# Altium schematic sheet 19internal_Mainboard.SchDoc(19internal_Mainboard)
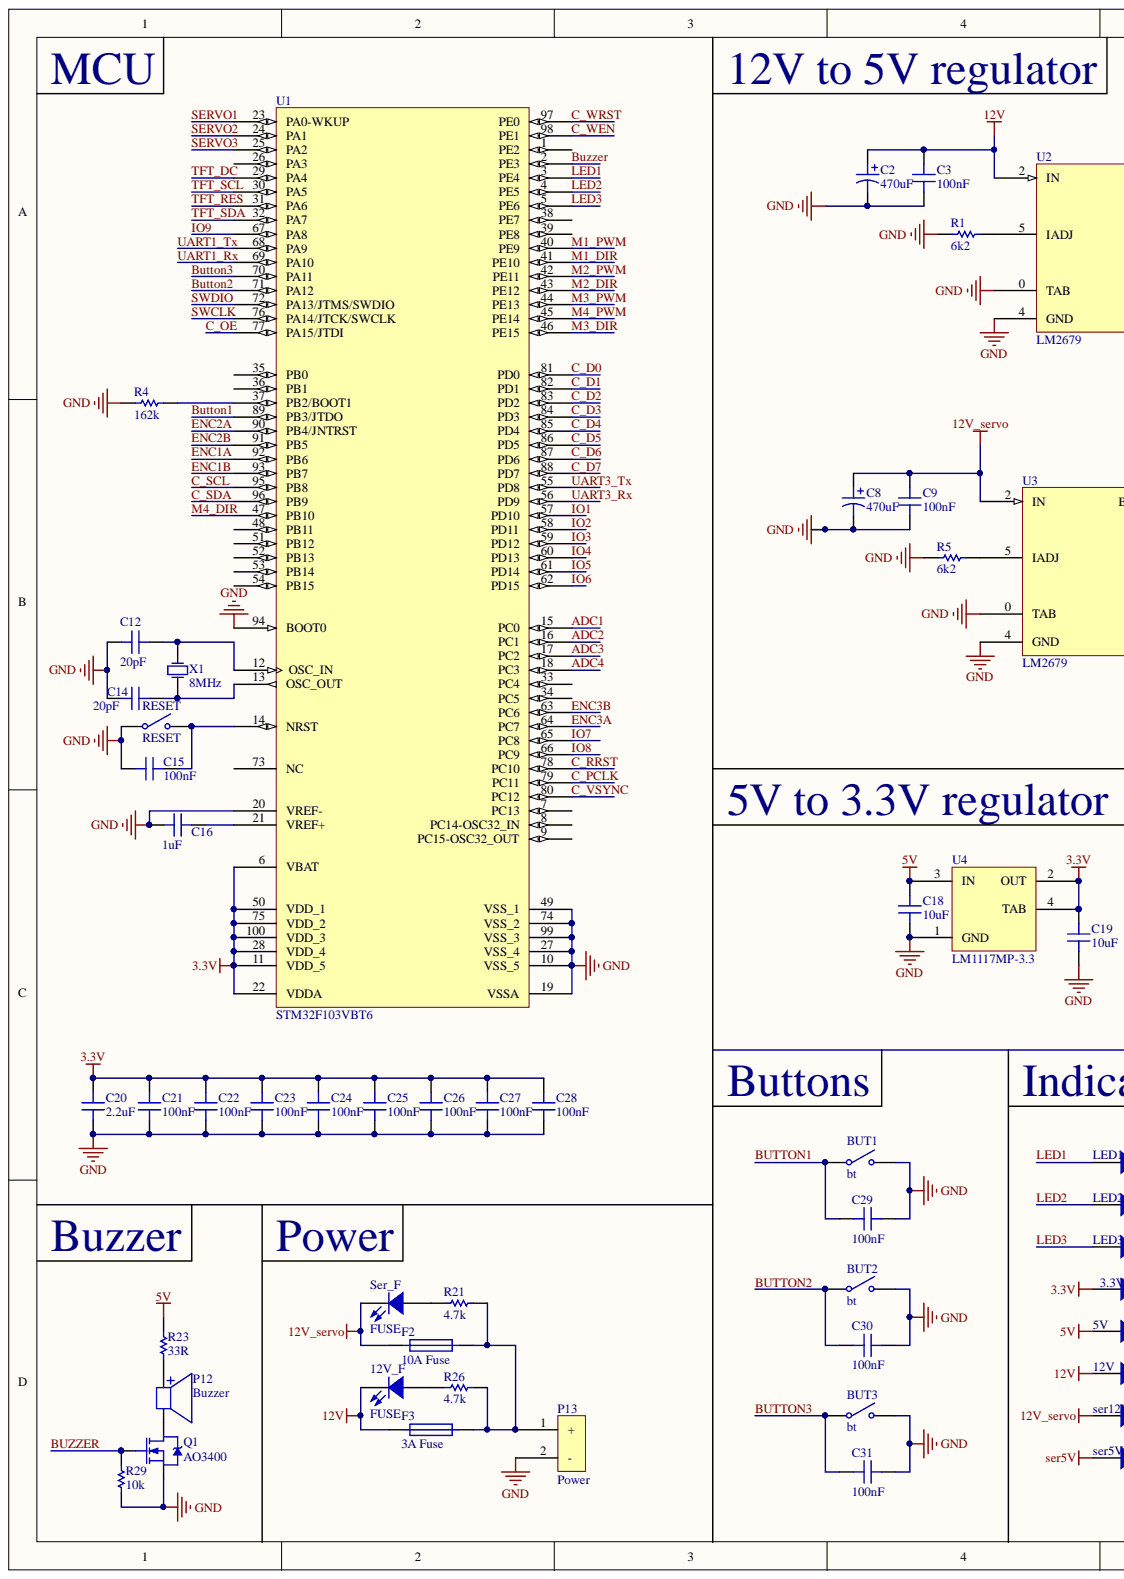
[diagram p0: 19internal_Mainboard.SchDoc, left]
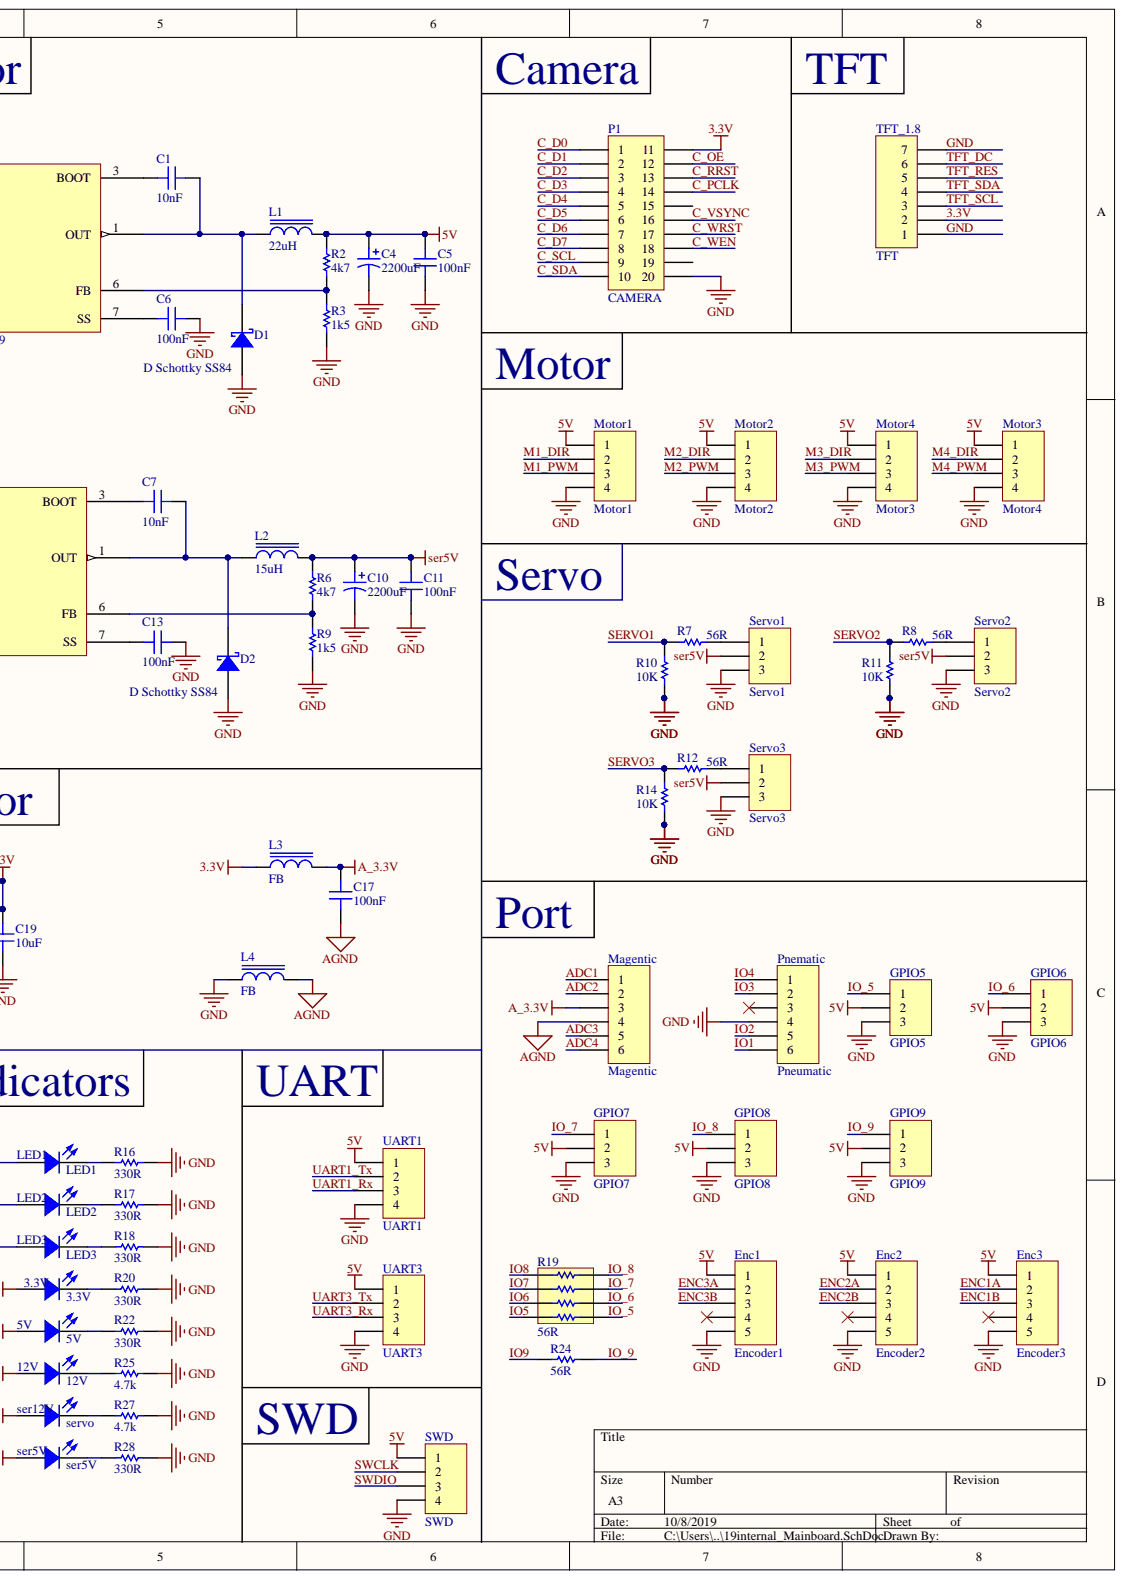
[diagram p1: 19internal_Mainboard.SchDoc, right]

## Parts
3.3V  3.3V  [D0805]
5V  5V  [D0805]
12V  12V  [D0805]
12V_F  FUSE  [D0805]
BUT1  bt  [PUSH SWITCH]
BUT2  bt  [PUSH SWITCH]
BUT3  bt  [PUSH SWITCH]
C1  10nF  [CC2012-0805]
C2  470uF  [CAP10x12]
C3  100nF  [CC2012-0805]
C4  2200uF  [SV-H]
C5  100nF  [CC2012-0805]
C6  100nF  [CC2012-0805]
C7  10nF  [CC2012-0805]
C8  470uF  [CAP10x12]
C9  100nF  [CC2012-0805]
C10  2200uF  [SV-H]
C11  100nF  [CC2012-0805]
C12  20pF  [CC2012-0805]
C13  100nF  [CC2012-0805]
C14  20pF  [CC2012-0805]
C15  100nF  [CC2012-0805]
C16  1uF  [CC2012-0805]
C17  100nF  [CC3216-1206]
C18  10uF  [CC2012-0805]
C19  10uF  [CC2012-0805]
C20  2.2uF  [CC2012-0805]
C21  100nF  [CC2012-0805]
C22  100nF  [CC2012-0805]
C23  100nF  [CC2012-0805]
C24  100nF  [CC2012-0805]
C25  100nF  [CC2012-0805]
C26  100nF  [CC2012-0805]
C27  100nF  [CC2012-0805]
C28  100nF  [CC2012-0805]
C29  100nF  [CC2012-0805]
C30  100nF  [CC2012-0805]
C31  100nF  [CC2012-0805]
D1  D Schottky SS84  [SMC]
D2  D Schottky SS84  [SMC]
Enc1  Encoder1  [lockedHDR-5]
Enc2  Encoder2  [lockedHDR-5]
Enc3  Encoder3  [lockedHDR-5]
F2  10A Fuse  [FUSE SOCKET]
F3  3A Fuse  [FUSE SOCKET]
GPIO5  GPIO5  [LOCKEDHDR-3]
GPIO6  GPIO6  [LOCKEDHDR-3]
GPIO7  GPIO7  [LOCKEDHDR-3]
GPIO8  GPIO8  [LOCKEDHDR-3]
GPIO9  GPIO9  [LOCKEDHDR-3]
L1  22uH  [MSS1260]
L2  15uH  [MSS1260]
L3  FB  [CL3225-1210]
L4  FB  [CL3225-1210]
LED1  LED1  [D0805]
LED2  LED2  [D0805]
LED3  LED3  [D0805]
Magentic  Magentic  [6410-06]
Motor1  Motor1  [LOCKEDHDR-4]
Motor2  Motor2  [LOCKEDHDR-4]
Motor3  Motor4  [LOCKEDHDR-4]
Motor4  Motor3  [LOCKEDHDR-4]
P1  CAMERA  [HDR2X10_CEN]
P12  Buzzer  [BUZ-9.0]
P13  Power  [XT60]
Pnematic  Pneumatic  [6410-06]
Q1  AO3400  [SO-G3/Z3.3]
R1  6k2  [CR2012-0805]
R2  4k7  [CR2012-0805]
R3  1k5  [CR2012-0805]
R4  162k  [CR2012-0805]
R5  6k2  [CR2012-0805]
R6  4k7  [CR2012-0805]
R7  56R  [CR2012-0805]
R8  56R  [CR2012-0805]
R9  1k5  [CR2012-0805]
R10  10K  [CR2012-0805]
R11  10K  [CR2012-0805]
R12  56R  [CR2012-0805]
R14  10K  [CR2012-0805]
R16  330R  [CR2012-0805]
R17  330R  [CR2012-0805]
R18  330R  [CR2012-0805]
R19  56R  [ARC241-0603x4]
R20  330R  [CR2012-0805]
R21  4.7k  [CR2012-0805]
R22  330R  [CR2012-0805]
R23  33R  [CR2012-0805]
R24  56R  [CR2012-0805]
R25  4.7k  [CR2012-0805]
R26  4.7k  [CR2012-0805]
R27  4.7k  [CR2012-0805]
R28  330R  [CR2012-0805]
R29  10k  [CR2012-0805]
RESET  RESET  [PUSH SWITCH 2-PIN]
ser5V  ser5V  [D0805]
ser12V  servo  [D0805]
Ser_F  FUSE  [D0805]
Servo1  Servo1  [LOCKEDHDR-3]
Servo2  Servo2  [LOCKEDHDR-3]
Servo3  Servo3  [LOCKEDHDR-3]
SWD  SWD  [LOCKEDHDR-4]
TFT_1.8  TFT  [HDR1X7]
U1  STM32F103VBT6  [LQFP100_L]
U2  LM2679  [TO-263(7)]
U3  LM2679  [TO-263(7)]
U4  LM1117MP-3.3  [MP04A_N]
UART1  UART1  [LOCKEDHDR-4]
UART3  UART3  [LOCKEDHDR-4]
X1  8MHz  [BCY-W2/E4.7]

## Nets
5V: 5V.1 C4.1 C5.1 C18.2 Enc1.1 Enc2.1 Enc3.1 GPIO5.2 GPIO6.2 GPIO7.2 GPIO8.2 GPIO9.2 L1.2 Motor1.1 Motor2.1 Motor3.1 Motor4.1 R2.2 R23.2 SWD.1 U4.3(IN) UART1.1 UART3.1
12V: 12V.1 12V_F.2 C2.1 C3.1 F3.1 U2.2(IN)
12V_servo: C8.1 C9.1 F2.1 ser12V.1 Ser_F.2 U3.2(IN)
A_3.3V: C17.2 L3.2 Magentic.3
AGND: C17.1 L4.2 Magentic.4
ser5V: C10.1 C11.1 L2.2 R6.2 ser5V.1 Servo1.2 Servo2.2 Servo3.2
3.3V: 3.3V.1 C19.2 C20.1 C21.2 C22.2 C23.2 C24.2 C25.2 C26.2 C27.2 C28.2 L3.1 P1.11 TFT_1.8.2 U1.6(VBAT) U1.11(VDD_5) U1.22(VDDA) U1.28(VDD_4) U1.50(VDD_1) U1.75(VDD_2) U1.100(VDD_3) U4.2(OUT) U4.4(TAB)
ADC1: Magentic.1 U1.15(PC0)
ADC2: Magentic.2 U1.16(PC1)
ADC3: Magentic.5 U1.17(PC2)
ADC4: Magentic.6 U1.18(PC3)
Button1: BUT1.1 C29.2 U1.89(PB3/JTDO)
Button2: BUT2.1 C30.2 U1.71(PA12)
Button3: BUT3.1 C31.2 U1.70(PA11)
BUZZER: Q1.1 R29.2 U1.2(PE3)
C_D0: P1.1 U1.81(PD0)
C_D1: P1.2 U1.82(PD1)
C_D2: P1.3 U1.83(PD2)
C_D3: P1.4 U1.84(PD3)
C_D4: P1.5 U1.85(PD4)
C_D5: P1.6 U1.86(PD5)
C_D6: P1.7 U1.87(PD6)
C_D7: P1.8 U1.88(PD7)
C_OE: P1.12 U1.77(PA15/JTDI)
C_PCLK: P1.14 U1.79(PC11)
C_RRST: P1.13 U1.78(PC10)
C_SCL: P1.9 U1.95(PB8)
C_SDA: P1.10 U1.96(PB9)
C_VSYNC: P1.16 U1.80(PC12)
C_WEN: P1.18 U1.98(PE1)
C_WRST: P1.17 U1.97(PE0)
ENC1A: Enc3.2 U1.92(PB6)
ENC1B: Enc3.3 U1.93(PB7)
ENC2A: Enc2.2 U1.90(PB4/JNTRST)
ENC2B: Enc2.3 U1.91(PB5)
ENC3A: Enc1.2 U1.64(PC7)
ENC3B: Enc1.3 U1.63(PC6)
GND: BUT1.2 BUT2.2 BUT3.2 C2.2 C3.2 C4.2 C5.2 C6.2 C8.2 C9.2 C10.2 C11.2 C12.1 C13.2 C14.1 C15.1 C16.1 C18.1 C19.1 C20.2 C21.1 C22.1 C23.1 C24.1 C25.1 C26.1 C27.1 C28.1 C29.1 C30.1 C31.1 D1.1 D2.1 Enc1.5 Enc2.5 Enc3.5 GPIO5.3 GPIO6.3 GPIO7.3 GPIO8.3 GPIO9.3 L4.1 Motor1.4 Motor2.4 Motor3.4 Motor4.4 P1.20 P13.2 Pnematic.4 Q1.2 R1.1 R3.1 R4.1 R5.1 R9.1 R10.1 R11.1 R14.1 R16.2 R17.2 R18.2 R20.2 R22.2 R25.2 R27.2 R28.2 R29.1 RESET.1 Servo1.3 Servo2.3 Servo3.3 SWD.4 TFT_1.8.1 TFT_1.8.7 U1.10(VSS_5) U1.19(VSSA) U1.20(VREF-) U1.27(VSS_4) U1.49(VSS_1) U1.74(VSS_2) U1.94(BOOT0) U1.99(VSS_3) U2.0(TAB) U2.4(GND) U3.0(TAB) U3.4(GND) U4.1(GND) UART1.4 UART3.4
IO1: Pnematic.6 U1.57(PD10)
IO2: Pnematic.5 U1.58(PD11)
IO3: Pnematic.2 U1.59(PD12)
IO4: Pnematic.1 U1.60(PD13)
IO5: R19.7 U1.61(PD14)
IO6: R19.5 U1.62(PD15)
IO7: R19.3 U1.65(PC8)
IO8: R19.1 U1.66(PC9)
IO9: R24.1 U1.67(PA8)
IO_5: GPIO5.1 R19.8
IO_6: GPIO6.1 R19.6
IO_7: GPIO7.1 R19.4
IO_8: GPIO8.1 R19.2
IO_9: GPIO9.1 R24.2
LED1: LED1.1 U1.3(PE4)
LED2: LED2.1 U1.4(PE5)
LED3: LED3.1 U1.5(PE6)
M1_DIR: Motor1.2 U1.41(PE10)
M1_PWM: Motor1.3 U1.40(PE9)
M2_DIR: Motor2.2 U1.43(PE12)
M2_PWM: Motor2.3 U1.42(PE11)
M3_DIR: Motor4.2 U1.46(PE15)
M3_PWM: Motor4.3 U1.44(PE13)
M4_DIR: Motor3.2 U1.47(PB10)
M4_PWM: Motor3.3 U1.45(PE14)
SERVO1: R7.1 R10.2 U1.23(PA0-WKUP)
SERVO2: R8.1 R11.2 U1.24(PA1)
SERVO3: R12.1 R14.2 U1.25(PA2)
SWCLK: SWD.2 U1.76(PA14/JTCK/SWCLK)
SWDIO: SWD.3 U1.72(PA13/JTMS/SWDIO)
TFT_DC: TFT_1.8.6 U1.29(PA4)
TFT_RES: TFT_1.8.5 U1.31(PA6)
TFT_SCL: TFT_1.8.3 U1.30(PA5)
TFT_SDA: TFT_1.8.4 U1.32(PA7)
UART1_Rx: U1.69(PA10) UART1.3
UART1_Tx: U1.68(PA9) UART1.2
UART3_Rx: U1.56(PD9) UART3.3
UART3_Tx: U1.55(PD8) UART3.2
Net3.3V_2: 3.3V.2 R20.1
Net5V_2: 5V.2 R22.1
Net12V_2: 12V.2 R25.1
Net12V_F_1: 12V_F.1 R26.1
NetC1_1: C1.1 U2.3(BOOT)
NetC1_2: C1.2 D1.2 L1.1 U2.1(OUT)
NetC6_1: C6.1 U2.7(SS)
NetC7_1: C7.1 U3.3(BOOT)
NetC7_2: C7.2 D2.2 L2.1 U3.1(OUT)
NetC12_2: C12.2 U1.12(OSC_IN) X1.2
NetC13_1: C13.1 U3.7(SS)
NetC14_2: C14.2 U1.13(OSC_OUT) X1.1
NetC15_2: C15.2 RESET.2 U1.14(NRST)
NetC16_2: C16.2 U1.21(VREF+)
NetF2_2: F2.2 F3.2 P13.1 R21.2 R26.2
NetLED1_2: LED1.2 R16.1
NetLED2_2: LED2.2 R17.1
NetLED3_2: LED3.2 R18.1
NetP12_1: P12.1 R23.1
NetP12_2: P12.2 Q1.3
NetR1_2: R1.2 U2.5(IADJ)
NetR2_1: R2.1 R3.2 U2.6(FB)
NetR4_2: R4.2 U1.37(PB2/BOOT1)
NetR5_2: R5.2 U3.5(IADJ)
NetR6_1: R6.1 R9.2 U3.6(FB)
NetR7_2: R7.2 Servo1.1
NetR8_2: R8.2 Servo2.1
NetR12_2: R12.2 Servo3.1
NetR21_1: R21.1 Ser_F.1
NetR27_1: R27.1 ser12V.2
NetR28_1: R28.1 ser5V.2
N00063:
N00064:

Not connected: Enc1 4; Enc2 4; Enc3 4; P1 15 19; Pnematic 3; U1 1(PE2) 7(PC13) 8(PC14-OSC32_IN) 9(PC15-OSC32_OUT) 26(PA3) 33(PC4) 34(PC5) 35(PB0) 36(PB1) 38(PE7) 39(PE8) 48(PB11) 51(PB12) 52(PB13) 53(PB14) 54(PB15) 73(NC)

## Library data of the parts
3.3V 5V 12V 12V_F LED1-LED3 ser5V ser12V Ser_F = LED: Typical RED GaAs LED; Published: 8-Jun-2000; PackageReference: LED-1; Library Name: Robocon_BJT.IntLib; LatestRevisionNote: Mech Layer 1 removed.; LatestRevisionDate: 08-Jul-2005; Component Kind: Standard; Publisher: Altium Limited
BUT1-BUT3 RESET = SW-SPST: Single-Pole, Single-Throw Switch; Revision: July-2002: Re-released for DXP Platform.; Published: 24-Mar-1999; Library Name: Robocn_connectors.IntLib; Component Kind: Standard; Publisher: Altium Limited
C1 C3 C5-C7 C9 C11-C31 = Cap Semi: Capacitor (Semiconductor SIM Model); Signal Integrity: Cap Semi; Published: 8-Jun-2000; PackageReference: C1206; Library Name: Robocon_RCL.IntLib; LatestRevisionNote: Re-released for DXP Platform.; LatestRevisionDate: 17-Jul-2002; Component Kind: Standard; Publisher: Altium Limited
C2 C8 = Cap Pol DIP: Polarized Capacitor (Radial); Published: 8-Jun-2000; Library Name: Robocon_RCL.IntLib; LatestRevisionNote: Re-released for DXP Platform.; LatestRevisionDate: 17-Jul-2002; Component Kind: Standard; Publisher: Altium Limited
C4 C10 = Cap Pol SMD: Polarized Capacitor (Axial); Published: 8-Jun-2000; Library Name: Robocon_RCL.IntLib; LatestRevisionNote: Re-released for DXP Platform.; LatestRevisionDate: 17-Jul-2002; Component Kind: Standard; Publisher: Altium Limited
D1 D2 = D Schottky: Schottky Diode; Code - JEDEC: DO-214-AA; Revision: July-2002: Re-released for DXP Platform.; Published: 8-Jun-2000; Library Name: Robocon_BJT.IntLib; Component Kind: Standard; Publisher: Altium Limited
Enc1-Enc3 = Header 5: Header, 5-Pin; Signal Integrity: Connector; Library Name: Robocn_connectors.IntLib; LatestRevisionNote: Re-released for DXP Platform.; LatestRevisionDate: 17-Jul-2002; Component Kind: Standard; Publisher: Altium Limited
F2 F3 = Fuse: Revision: July-2002: Re-released for DXP Platform.; Published: 8-Jun-2000; Library Name: Robocon_RCL.IntLib; Description: Fuse; Component Kind: Standard; Publisher: Altium Limited
GPIO5-GPIO9 Servo1-Servo3 = Header 3: Header, 3-Pin; Signal Integrity: Connector; Revision: 17-Jul-2002: Re-released for DXP Platform.; Library Name: Robocn_connectors.IntLib; Component Kind: Standard; Publisher: Altium Limited
L1-L4 = Inductor: Value: 10uH; Revision: July-2002: Re-released for DXP Platform.; Published: 8-Jun-2000; Library Name: Robocon_RCL.IntLib; Description: Inductor; Component Kind: Standard; Publisher: Altium Limited
Magentic Pnematic = Header 6: Header, 6-Pin; Library Name: Robocn_connectors.IntLib; LatestRevisionNote: Re-released for DXP Platform.; LatestRevisionDate: 17-Jul-2002; Component Kind: Standard; Publisher: Altium Limited
Motor1-Motor4 SWD UART1 UART3 = Header 4: Header, 4-Pin; Signal Integrity: Connector; Revision: 17-Jul-2002: Re-released for DXP Platform.; Library Name: Robocn_connectors.IntLib; Component Kind: Standard; Publisher: Altium Limited
P1 = Header 10X2A: Header, 10-Pin, Dual row; Signal Integrity: Connector; Library Name: Miscellaneous Connectors.IntLib; LatestRevisionNote: Re-released for DXP Platform.; LatestRevisionDate: 17-Jul-2002; Component Kind: Standard; Publisher: Altium Limited
P13 = XT_ _: Component Kind: Standard; Library Name: CLJ_connectors.IntLib
Q1 = AO3400: N-Channel MOSFET; Revision: July-2002: Re-released for DXP Platform.; Published: 8-Jun-2000; Library Name: Robocon_BJT.IntLib; Component Kind: Standard; Publisher: Altium Limited
R1-R12 R14 R16-R18 R20-R29 = Res Semi: Semiconductor Resistor; Signal Integrity: Res Semi; Published: 8-Jun-2000; Library Name: Robocon_RCL.IntLib; LatestRevisionNote: Re-released for DXP Platform.; LatestRevisionDate: 17-Jul-2002; Component Kind: Standard; Publisher: Altium Limited
R19 = Res Array: 4x Resistor Array; Signal Integrity: Res Semi; Published: 8-Jun-2000; Library Name: Robocon_RCL.IntLib; LatestRevisionNote: Re-released for DXP Platform.; LatestRevisionDate: 17-Jul-2002; Component Kind: Standard; Publisher: Altium Limited
TFT_1.8 = Header 7: Header, 6-Pin; Library Name: Robocn_connectors.IntLib; LatestRevisionNote: Re-released for DXP Platform.; LatestRevisionDate: 17-Jul-2002; Component Kind: Standard; Publisher: Altium Limited
U1 = STM32F103VBT6: STM32 ARM-based 32-bit MCU with 64 Kbytes Flash, 100-pin LQFP, Industrial Temperature; Published: 30-May-2008; PackageVersion: Mar-2008; PackageReference: LQFP100; PackageDescription: 100-Pin Low-Profile Quad Flat Pack, 14 x 14 mm Body, 0.5 mm Pitch (LQFP100); Library Name: Robocon_IC.IntLib; DatasheetVersion: rev6, Mar-2008; ComponentLink2URL: http://www.st.com/stonline/products/literature/ds/13587.pdf; ComponentLink2Description: Datasheet; ComponentLink1URL: www.st.com/stm32; ComponentLink1Description: Manufacturer Link; Component Kind: Standard; Publisher: Altium Limited
U2 U3 = LM2679: 5A Step-Down Switching Mode Voltage Regulator; Library Name: Robocon_IC.IntLib; Component Kind: Standard
U4 = LM1117MP-3.3: 800mA Low-Dropout Linear Regulator; Manufacturer: National Semiconductor; PackageTopMarking: XYTT*N05A; CompType: LDO IC; RoHS: O; Published: 27-Nov-2008; PinCount: 4; PartNumber: LM1117MP-3.3; PackageVersion: revB, Apr-2003; PackageReference: MP04A; PackageDescription: Molded SOT-223, 6.50 x 3.56 x 1.6mm Body, 4 Lead, 2.3mm Pitch; Library Name: Robocon_IC.IntLib; DigiKeyPartNumber: LM1117MP-3.3CT-ND; DatasheetVersion: Apr-2006; ComponentLink3URL: http://www.national.com/packaging/folders/mp04a.html; ComponentLink3Description: MP04A Package Page; ComponentLink2URL: http://www.national.com/pf/LM/LM1117.html; ComponentLink2Description: LM1117 Web Page; ComponentLink1URL: http://www.national.com/ds/LM/LM1117.pdf; ComponentLink1Description: LM1117 Datasheet; Component Kind: Standard; Code_JEDEC: TO-261-AA; Code_IPC: SOT223-4; Publisher: Altium Limited
X1 = XTAL: Crystal Oscillator; Revision: July-2002: Re-released for DXP Platform.; Published: 8-Jun-2000; Library Name: Robocon_RCL.IntLib; Component Kind: Standard; Publisher: Altium Limited
P12 = Buzzer: Library Name: Robocon_RCL.IntLib; Component Kind: Standard
